# Altium schematic sheet 07 Ethernet_RJ45 CONN.SchDoc
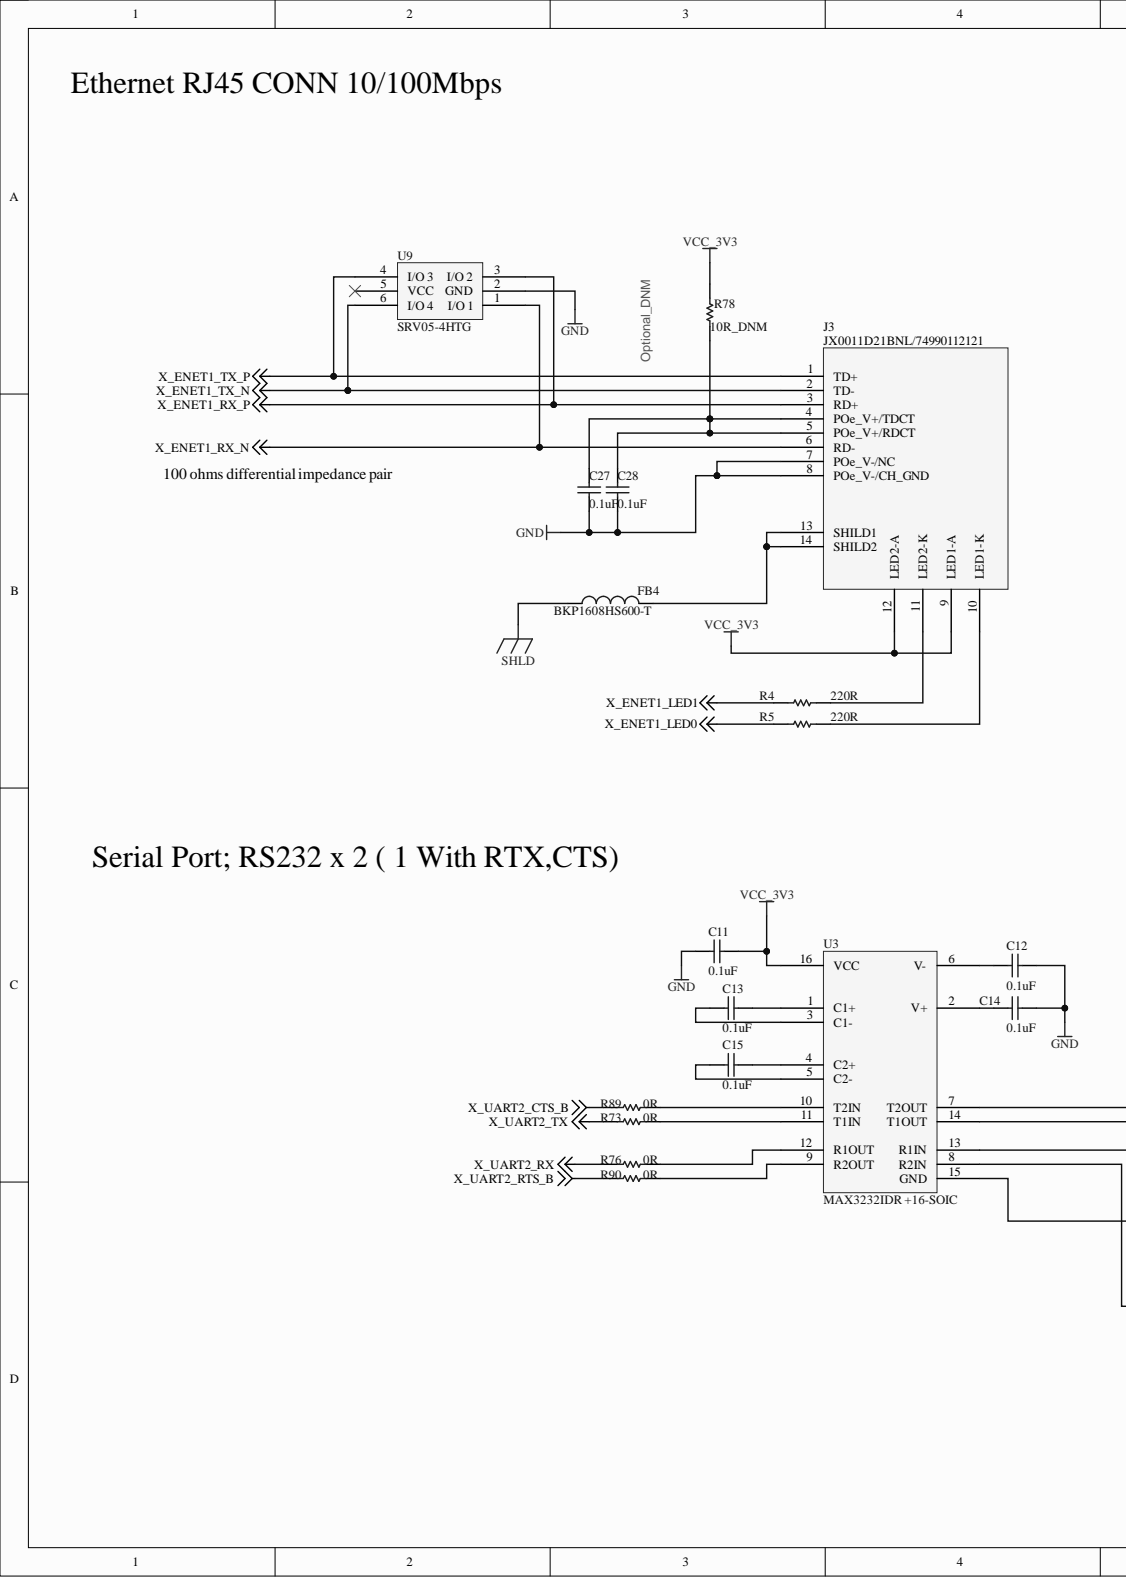
[diagram p0: 07 Ethernet_RJ45 CONN.SchDoc, left]
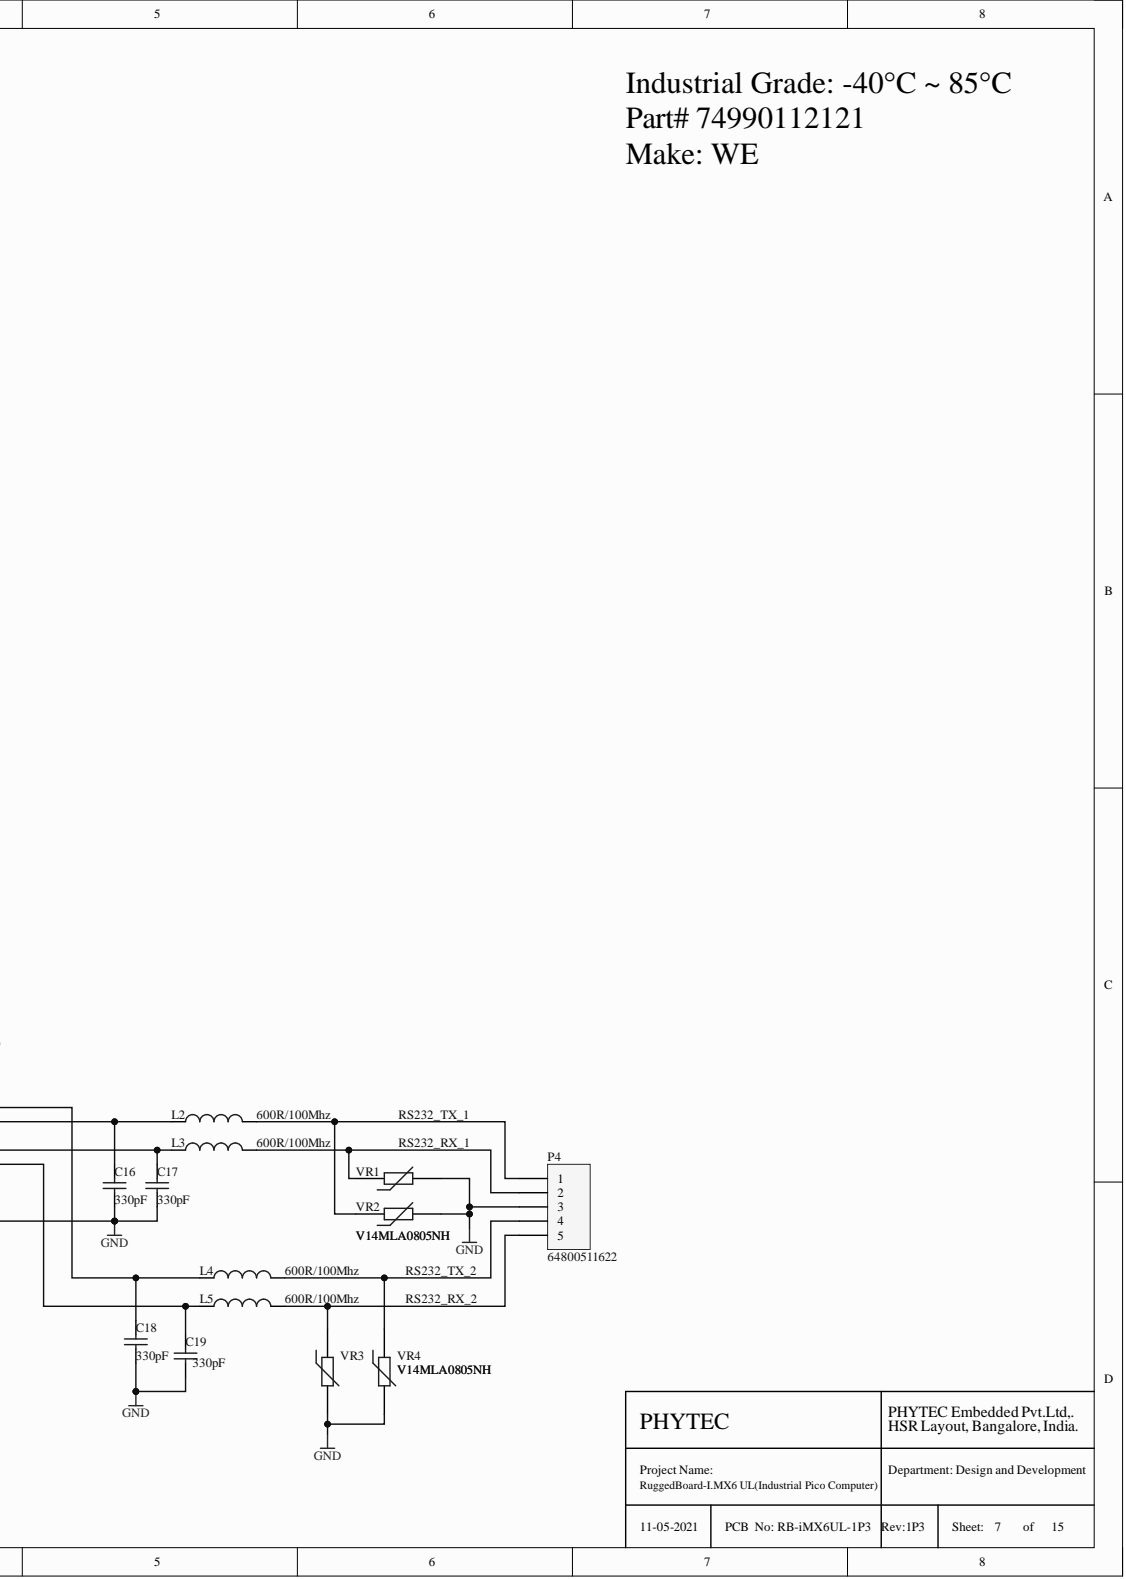
[diagram p1: 07 Ethernet_RJ45 CONN.SchDoc, right]

## Parts
C11  0.1uF  [c0402]
C12  0.1uF  [c0402]
C13  0.1uF  [c0402]
C14  0.1uF  [c0402]
C15  0.1uF  [c0402]
C16  330pF  [C0402]
C17  330pF  [C0402]
C18  330pF  [C0402]
C19  330pF  [C0402]
C27  0.1uF  [C0402]
C28  0.1uF  [C0402]
FB4  BKP1608HS600-T  [L0603]
J3  JX0011D21BNL/74990112121  [BNL_RJ45_R8_LED]
L2  600R/100Mhz  [L0402]
L3  600R/100Mhz  [L0402]
L4  600R/100Mhz  [L0402]
L5  600R/100Mhz  [L0402]
P4  64800511622  [HEADER_15P_1X5N]
R4  220R  [R0402]
R5  220R  [R0402]
R73  0R  [R0402]
R76  0R  [R0402]
R78  10R_DNM  [R0603]
R89  0R  [R0402]
R90  0R  [R0402]
U3  MAX3232IDR +16-SOIC  MFG_PN: MAX3232IDR  [SOIC127P600X175-16N]
U9  SRV05-4HTG  [SOT95P280X145-6N]
VR1  V14MLA0805NH  [C0805]
VR2  V14MLA0805NH  [C0805]
VR3  V14MLA0805NH  [C0805]
VR4  V14MLA0805NH  [C0805]

## Nets
GND: C11.1 C12.2 C14.2 C16.1 C17.1 C18.1 C19.1 C27.1 C28.1 J3.7(POe_V-/NC) J3.8(POe_V-/CH_GND) P4.3 U3.15(GND) U9.2(GND) VR1.2 VR2.2 VR3.2 VR4.2
SHLD: FB4.1
VCC_3V3: C11.2 J3.9(LED1-A) J3.12(LED2-A) R78.1 U3.16(VCC)
X_ENET1_LED0: R5.2
X_ENET1_LED1: R4.2
X_ENET1_RX_N: J3.6(RD-) U9.1(I/O_1)
X_ENET1_RX_P: J3.3(RD+) U9.3(I/O_2)
X_ENET1_TX_N: J3.2(TD-) U9.6(I/O_4)
X_ENET1_TX_P: J3.1(TD+) U9.4(I/O_3)
X_UART2_CTS_B: R89.2
X_UART2_RTS_B: R90.2
X_UART2_RX: R76.2
X_UART2_TX: R73.2
NetC12_1: C12.1 U3.6(V-)
NetC13_1: C13.1 U3.3(C1-)
NetC13_2: C13.2 U3.1(C1+)
NetC14_1: C14.1 U3.2(V+)
NetC15_1: C15.1 U3.5(C2-)
NetC15_2: C15.2 U3.4(C2+)
NetC16_2: C16.2 L2.1 U3.14(T1OUT)
NetC17_2: C17.2 L3.1 U3.13(R1IN)
NetC18_2: C18.2 L4.1 U3.7(T2OUT)
NetC19_2: C19.2 L5.1 U3.8(R2IN)
NetC27_2: C27.2 C28.2 J3.4(POe_V+/TDCT) J3.5(POe_V+/RDCT) R78.2
NetFB4_2: FB4.2 J3.13(SHILD1) J3.14(SHILD2)
NetJ3_10: J3.10(LED1-K) R5.1
NetJ3_11: J3.11(LED2-K) R4.1
NetL2_2: L2.2 P4.1 VR2.1
NetL3_2: L3.2 P4.2 VR1.1
NetL4_2: L4.2 P4.4 VR4.1
NetL5_2: L5.2 P4.5 VR3.1
NetR73_1: R73.1 U3.11(T1IN)
NetR76_1: R76.1 U3.12(R1OUT)
NetR89_1: R89.1 U3.10(T2IN)
NetR90_1: R90.1 U3.9(R2OUT)

Not connected: U9 5(VCC)

## Library data of the parts
C11-C15 = Cap: CAP CER 0.1UF 50V X7R 0402; ASSEMBLY_TYPE: SMT; MFG: Murata; MFG_PN: GRM155R71H104ME14D; Component Kind: Standard; Library Name: Miscellaneous Devices.IntLib; PACKAGE: 0402; Signal Integrity: Cap; Simulation: CAP
C16-C19 = Cap: CAP CER 330PF 50V NP0 0402; ASSEMBLY_TYPE: SMT; MFG: Murata; MFG_PN: GRM1555C1H331JA01D; Component Kind: Standard; Library Name: Miscellaneous Devices.IntLib; PACKAGE: 0402; Signal Integrity: Cap; Simulation: CAP
C27 C28 = Cap: CAP CER 0.1UF 50V X7R 0402; ASSEMBLY_TYPE: SMT; MACRO: Power Management (VYS, 5V, 3.3V and Reset); MFG: Taiyo Yuden; MFG_PN: UMK105B7104KV-FR; Component Kind: Standard; Library Name: Miscellaneous Devices.IntLib; PACKAGE: 0402; Signal Integrity: Cap; Simulation: CAP; TEMPERATURE: -55°C ~ 125°C
FB4 = Inductor: FERRITE BEAD 60 OHM 0603 1LN; ASSEMBLY_TYPE: SMT; MACRO: Power For Ethernet PHY Transceiver; MFG: Taiyo Yuden; Component Kind: Standard; Library Name: Miscellaneous Devices.IntLib; MFG_PN: BKP1608HS600-T; PACKAGE: 0603; Signal Integrity: Inductor; Simulation: INDUCTOR; TEMPERATURE: -55°C ~ 85°C
J3 = RJ45_J0011D21BNL: CONN MAGJACK 1PORT 100 BASE-TX; ASSEMBLY_TYPE: TH; MFG: PULSE Electronics/WE; Component Kind: Standard; MFG_PN: JX0011D21BNL/74990112121; Library Name: PHY_LIBRARY.SchLib
L2-L5 = Inductor: FERRITE BEAD 600 OHM 0402 1LN; ASSEMBLY_TYPE: SMT; MFG: Murata; MFG_PN: BLM15AG601SN1D; Component Kind: Standard; Library Name: Miscellaneous Devices.IntLib; PACKAGE: 0402; Signal Integrity: Inductor; Simulation: INDUCTOR
P4 = Header 5: CONN HEADER ZH TOP 5POS 1.5MM; MFG: WE; Component Kind: Standard; Library Name: Miscellaneous Connectors.IntLib; MFG_PN: 64800511622
R4 R5 = Res1: RES SMD 220 OHM 1% 1/16W 0402; ASSEMBLY_TYPE: SMT; MFG: Yageo; MFG_PN: RC0402FR-07220RL; Component Kind: Standard; Library Name: Miscellaneous Devices.IntLib; PACKAGE: 0402; Signal Integrity: Res; Simulation: RESISTOR
R73 R76 R89 R90 = Res1: RES 0 OHM JUMPER 1/16W 0402; ASSEMBLY_TYPE: SMT; MFG: Stackpole Electronics Inc.; MFG_PN: RMCF0402ZT0R00; Component Kind: Standard; Library Name: Miscellaneous Devices.IntLib; PACKAGE: 0402
R78 = Res1: RES SMD 10 OHM 5% 1/10W 0603; ASSEMBLY_TYPE: SMT; MFG: YAGE0; MFG_PN: RC0603JR-0710RL; Component Kind: Standard; Library Name: Miscellaneous Devices.IntLib; PACKAGE: 0603
U3 = MAX3232ESE+16-SOIC: IC DRVR/RCVR MULTCH RS232 16SOIC; MFG: TI; ASSEMBLY_TYPE: 16-SOIC; Component Kind: Standard; PACKAGE: SMT; Library Name: PHY_LIBRARY.SchLib
U9 = SRV05-4HTG: TVS DIODE 6V 15V SOT23-6; ASSEMBLY_TYPE: SMD; MFG: Littelfuse; Component Kind: Standard; MFG_PN: SRV05-4HTG; PACKAGE: SOT-23-6; Library Name: PHY_LIBRARY.SchLib
VR1-VR3 = Res Varistor: VARISTOR 18.1V 120A 0805; MFG: Littelfuse Inc.; MFG2_PN: 82550110; Component Kind: Standard; Library Name: Miscellaneous Devices.IntLib; MFG2: WE; MFG_PN: V14MLA0805NH; PACKAGE: 0805
VR4 = Res Varistor: VARISTOR 18.1V 120A 0805; MFG: Littelfuse Inc.; Component Kind: Standard; Library Name: Miscellaneous Devices.IntLib; MFG_PN: V14MLA0805NH; PACKAGE: 0805
